# Altium schematic sheet Front end power supplies.SchDoc
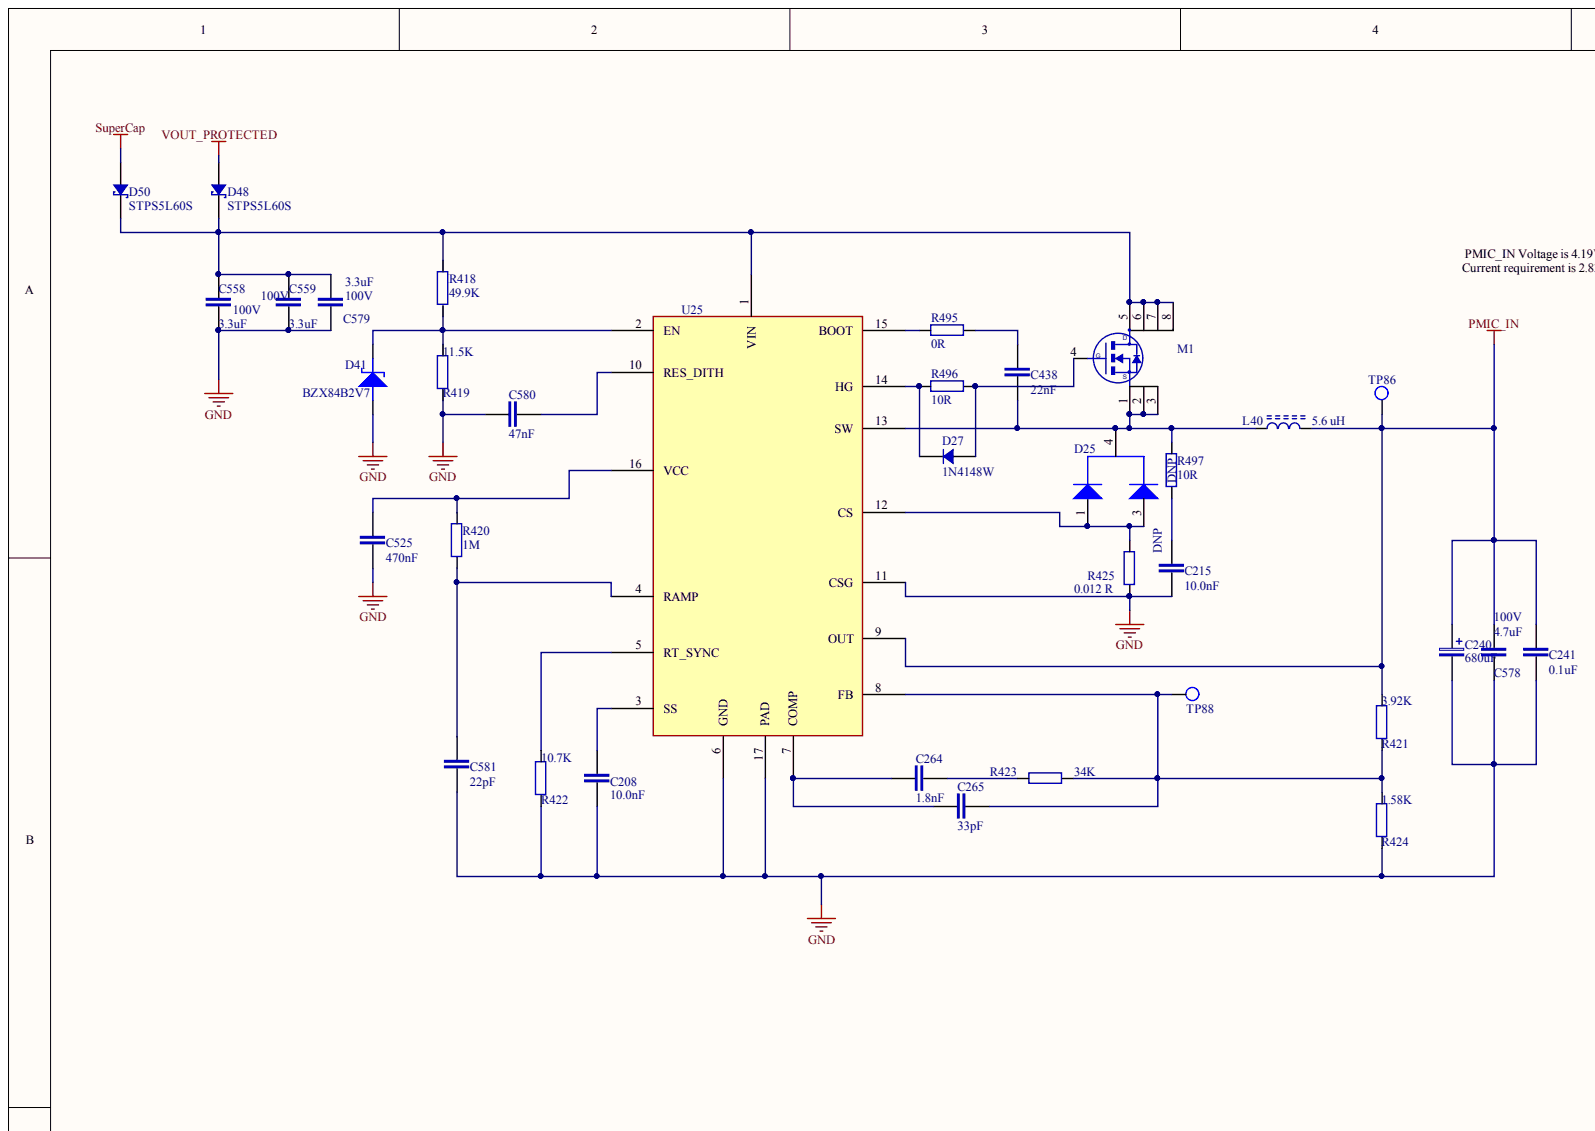
[diagram p0: Front end power supplies.SchDoc, top left]
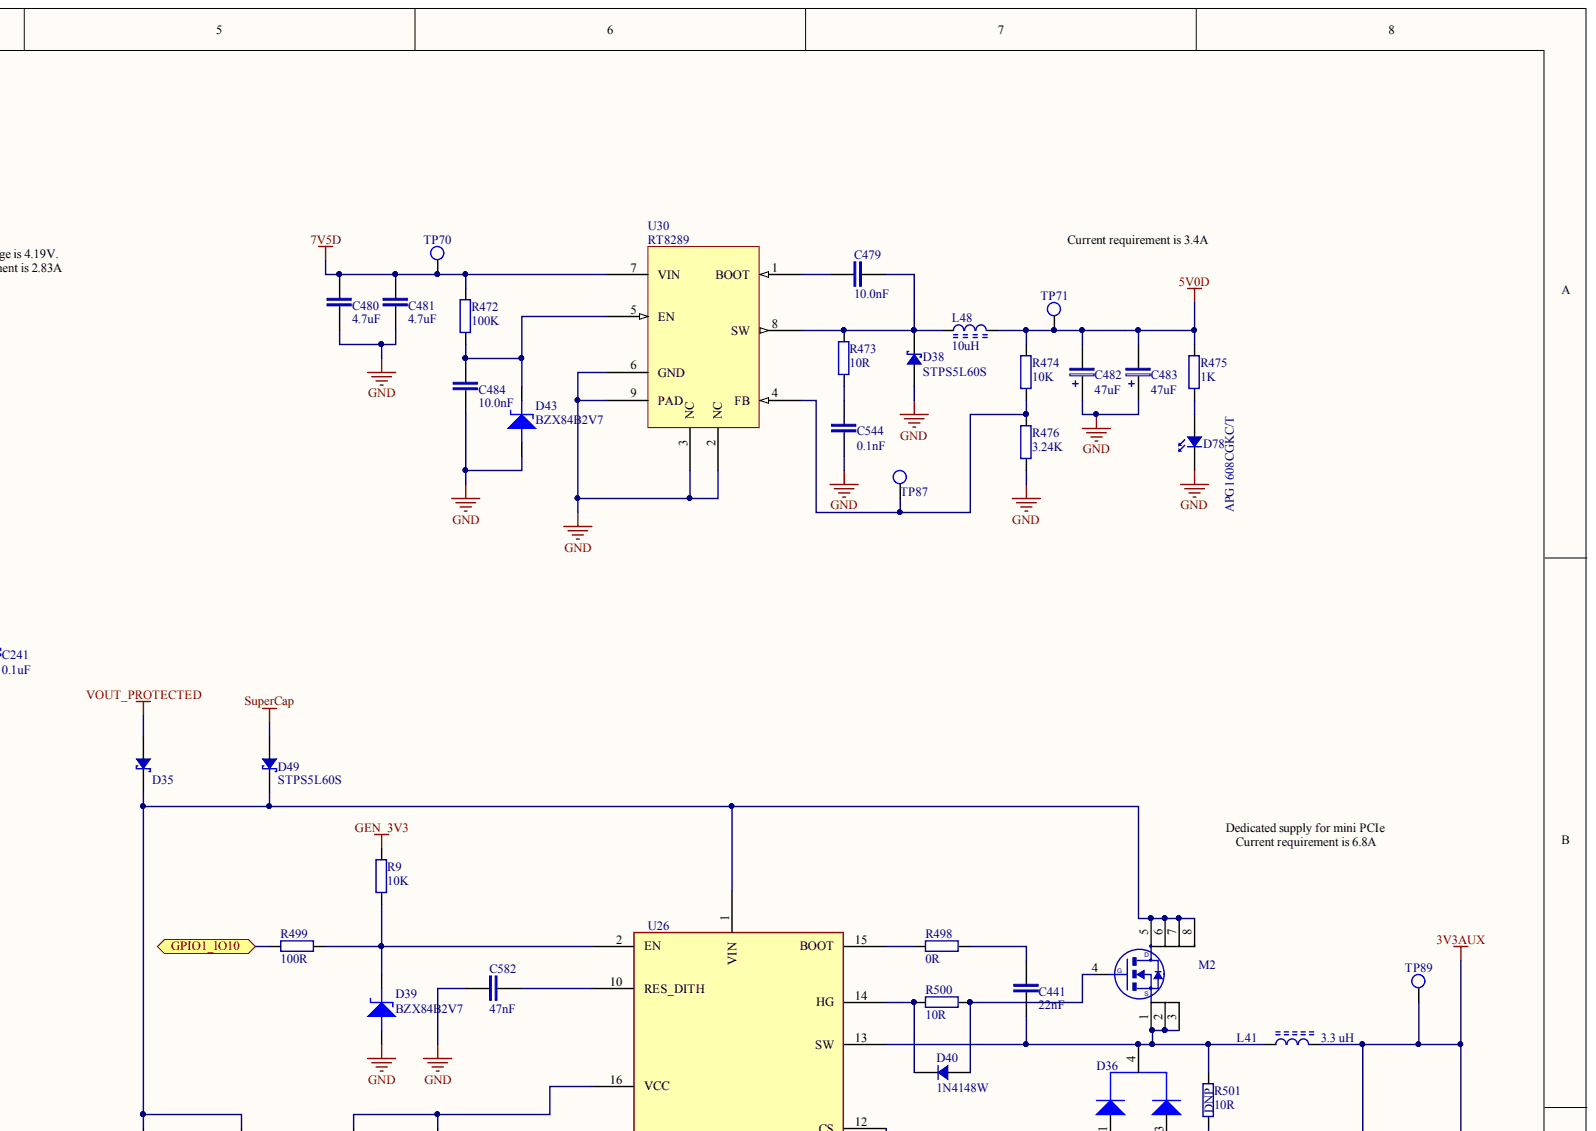
[diagram p1: Front end power supplies.SchDoc, top right]
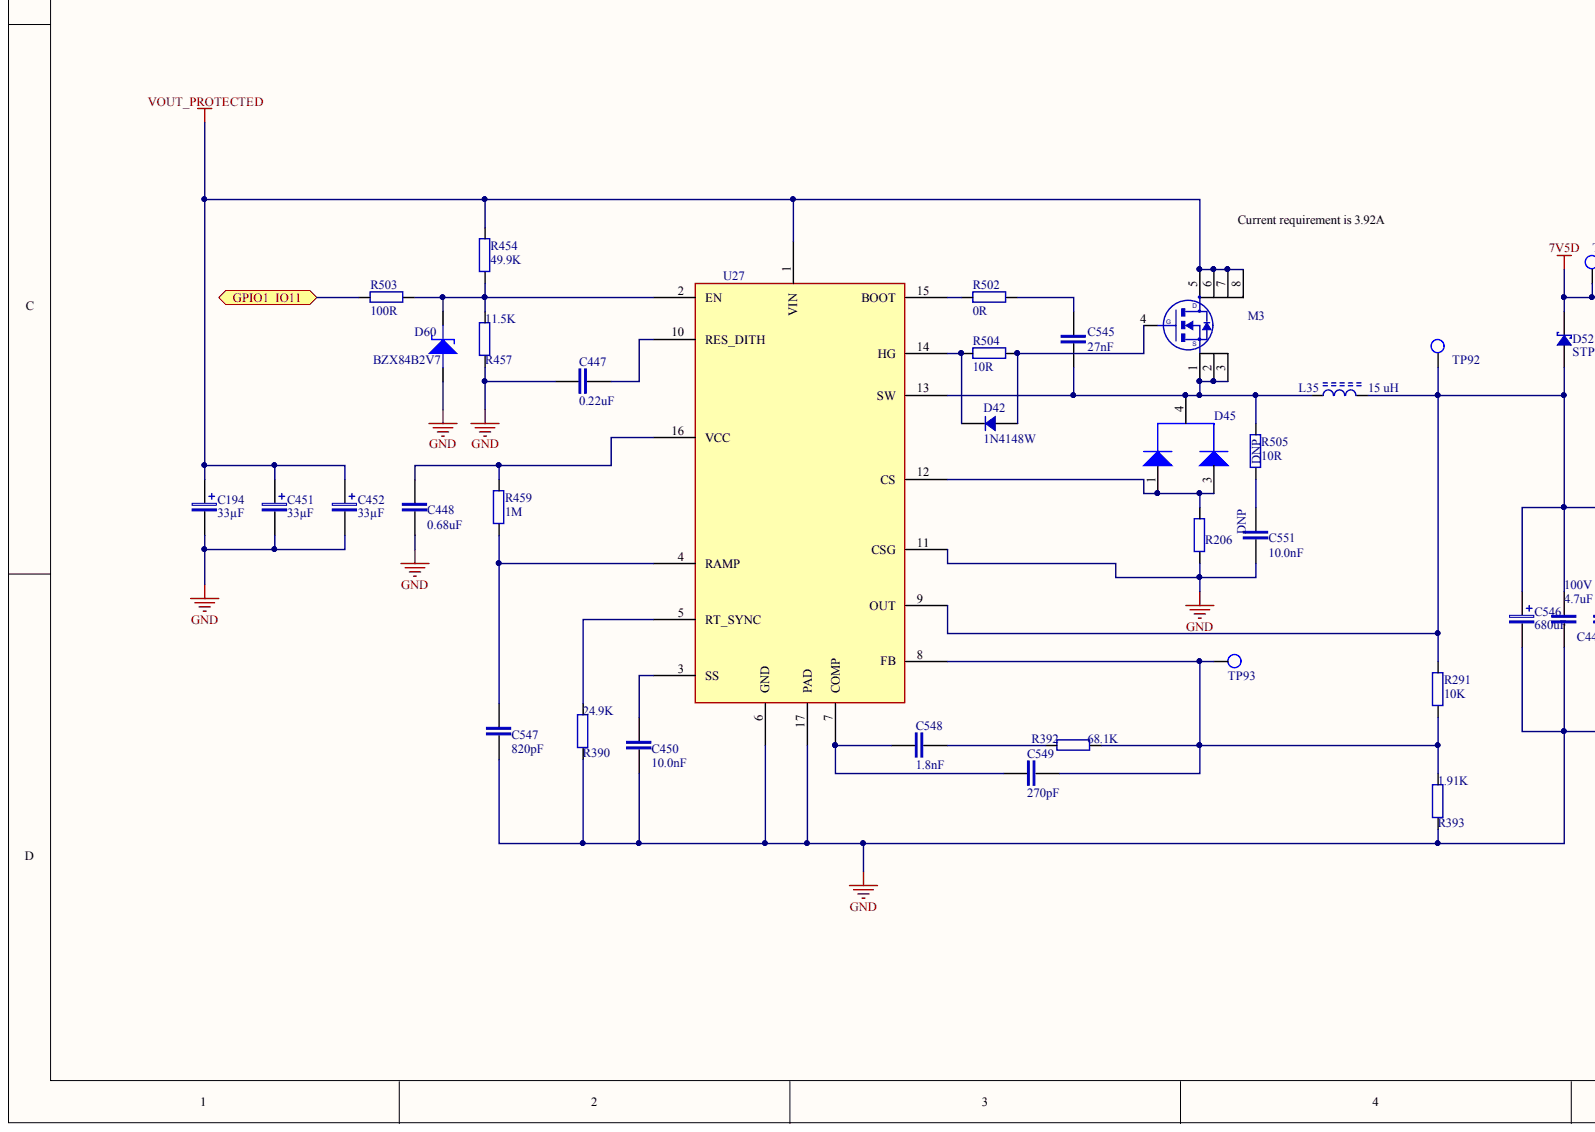
[diagram p2: Front end power supplies.SchDoc, bottom left]
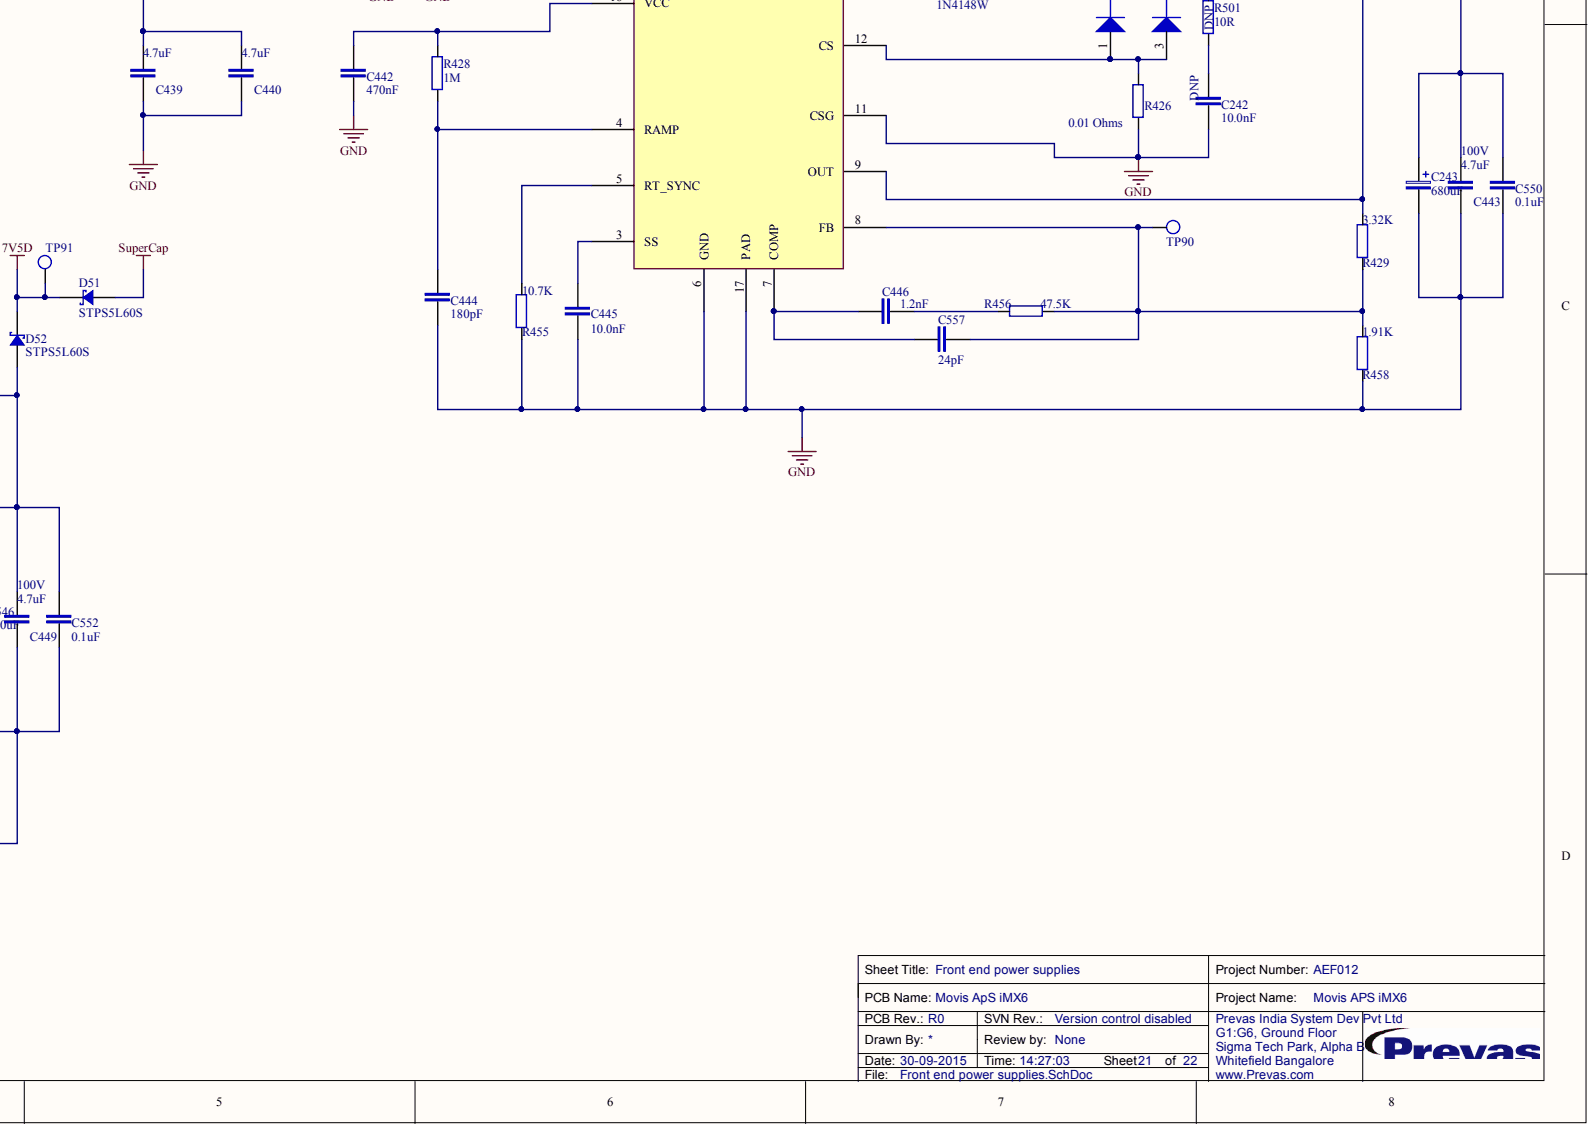
[diagram p3: Front end power supplies.SchDoc, bottom right]

## Parts
C194  33µF  [CAPAE110103X145N]
C208  10.0nF  [CAPC1005X55N]
C215  10.0nF  Fitted: DNP  [CAPC1005X55N]
C240  680uF  [CAPAE8383X10N]
C241  0.1uF  [CAPC1005X55N]
C242  10.0nF  Fitted: DNP  [CAPC1005X55N]
C243  680uF  [CAPAE8383X10N]
C264  1.8nF  [CAPC1005X55N]
C265  33pF  [CAPC1005X55N]
C438  22nF  [CAPC1005X55N]
C439  4.7uF  [CAPC3225X220N]
C440  4.7uF  [CAPC3225X220N]
C441  22nF  [CAPC1005X55N]
C442  470nF  [CAPC1005X55N]
C443  4.7uF  elec_voltage_rating: 100V  [CAPC3225X220N]
C444  180pF  [CAPC1005X55N]
C445  10.0nF  [CAPC1005X55N]
C446  1.2nF  [CAPC1005X55N]
C447  0.22uF  [CAPC1005X55N]
C448  0.68uF  [CAPC1608X95N]
C449  4.7uF  elec_voltage_rating: 100V  [CAPC3225X220N]
C450  10.0nF  [CAPC1005X55N]
C451  33µF  [CAPAE110103X145N]
C452  33µF  [CAPAE110103X145N]
C479  10.0nF  [CAPC1005X55N]
C480  4.7uF  [CAPC1608X90N]
C481  4.7uF  [CAPC1608X90N]
C482  47uF  [CAPMP6032X26N]
C483  47uF  [CAPMP6032X26N]
C484  10.0nF  [CAPC1005X55N]
C525  470nF  [CAPC1005X55N]
C544  0.1nF  [CAPC1005X55N]
C545  27nF  [CAPC1005X55N]
C546  680uF  [CAPAE8383X10N]
C547  820pF  [CAPC1005X55N]
C548  1.8nF  [CAPC1005X55N]
C549  270pF  [CAPC1005X55N]
C550  0.1uF  [CAPC1005X55N]
C551  10.0nF  Fitted: DNP  [CAPC1005X55N]
C552  0.1uF  [CAPC1005X55N]
C557  24pF  [CAPC1005X55N]
C558  3.3uF  elec_voltage_rating: 100V  [CAPC3225X220N]
C559  3.3uF  elec_voltage_rating: 100V  [CAPC3225X220N]
C578  4.7uF  elec_voltage_rating: 100V  [CAPC3225X220N]
C579  3.3uF  elec_voltage_rating: 100V  [CAPC3225X220N]
C580  47nF  [CAPC1005X55N]
C581  22pF  [CAPC1005X55N]
C582  47nF  [CAPC1005X55N]
D25  30CTH02SPbF  [TO254P1524X483-3_4N]  (value not drawn)
D27  1N4148W  [SOD3716X135N]
D35  SK810L-TP  [DIOM7959X262N]  (value not drawn)
D36  30CTH02SPbF  [TO254P1524X483-3_4N]  (value not drawn)
D38  STPS5L60S  [DIOM7959X265N]
D39  BZX84B2V7  [SOT95P240X114-3N]
D40  1N4148W  [SOD3716X135N]
D41  BZX84B2V7  [SOT95P240X114-3N]
D42  1N4148W  [SOD3716X135N]
D43  BZX84B2V7  [SOT95P240X114-3N]
D45  30CTH02SPbF  [TO254P1524X483-3_4N]  (value not drawn)
D48  STPS5L60S  [DIOM7959X265N]
D49  STPS5L60S  [DIOM7959X265N]
D50  STPS5L60S  [DIOM7959X265N]
D51  STPS5L60S  [DIOM7959X265N]
D52  STPS5L60S  [DIOM7959X265N]
D60  BZX84B2V7  [SOT95P240X114-3N]
D78  APG1608CGKC/T  [Kingbright_APG1608CGKC-T]
L35  15 uH  [IND_XAL1010-153MEB]
L40  5.6 uH  [IND_XAL6060-562MEB]
L41  3.3 uH  [IND_XAL7070-332MEB]
L48  10uH  [IND_RLF12545T-100M5R1-PF]
M1  CSD19533Q5A  [SON127P600X110_HS-8N]  (value not drawn)
M2  CSD19533Q5A  [SON127P600X110_HS-8N]  (value not drawn)
M3  CSD19533Q5A  [SON127P600X110_HS-8N]  (value not drawn)
R9  10K  [RESC1608X55N]
R206  0.009 Ohms  [RESC1733X06N]  (value not drawn)
R291  10K  [RESC1608X55N]
R390  24.9K  [RESC1608X55N]
R392  68.1K  [RESC1608X55N]
R393  1.91K  [RESC1608X55N]
R418  49.9K  [RESC1608X55N]
R419  11.5K  [RESC1608X55N]
R420  1M  [RESC1608X55N]
R421  3.92K  [RESC1608X55N]
R422  10.7K  [RESC1608X55N]
R423  34K  [RESC1608X55N]
R424  1.58K  [RESC1608X55N]
R425  0.012 R  [RESC3216X65N]
R426  0.01 Ohms  [RESC1733X06N]
R428  1M  [RESC1608X55N]
R429  3.32K  [RESC1608X55N]
R454  49.9K  [RESC1608X55N]
R455  10.7K  [RESC1608X55N]
R456  47.5K  [RESC1608X55N]
R457  11.5K  [RESC1608X55N]
R458  1.91K  [RESC1608X55N]
R459  1M  [RESC1608X55N]
R472  100K  [RESC1608X55N]
R473  10R  [RESC1608X55N]
R474  10K  [RESC1608X55N]
R475  1K  [RESC1608X55N]
R476  3.24K  [RESC1608X55N]
R495  0R  [RESC3216X65N]
R496  10R  [RESC1608X55N]
R497  10R  Fitted: DNP  [RESC1608X55N]
R498  0R  [RESC3216X65N]
R499  100R  [RESC1608X55N]
R500  10R  [RESC1608X55N]
R501  10R  Fitted: DNP  [RESC1608X55N]
R502  0R  [RESC3216X65N]
R503  100R  [RESC1608X55N]
R504  10R  [RESC1608X55N]
R505  10R  Fitted: DNP  [RESC1608X55N]
TP70  Testpoint  [TP_SMD]  (value not drawn)  (no BOM)
TP71  Testpoint  [TP_SMD]  (value not drawn)  (no BOM)
TP86  Testpoint  [TP_SMD]  (value not drawn)  (no BOM)
TP87  Testpoint  [TP_SMD]  (value not drawn)  (no BOM)
TP88  Testpoint  [TP_SMD]  (value not drawn)  (no BOM)
TP89  Testpoint  [TP_SMD]  (value not drawn)  (no BOM)
TP90  Testpoint  [TP_SMD]  (value not drawn)  (no BOM)
TP91  Testpoint  [TP_SMD]  (value not drawn)  (no BOM)
TP92  Testpoint  [TP_SMD]  (value not drawn)  (no BOM)
TP93  Testpoint  [TP_SMD]  (value not drawn)  (no BOM)
U25  LM5088MH-1_SCH  [SOP65P640X110-17BN]  (value not drawn)
U26  LM5088MH-1_SCH  [SOP65P640X110-17BN]  (value not drawn)
U27  LM5088MH-1_SCH  [SOP65P640X110-17BN]  (value not drawn)
U30  RT8289  [SOIC127P600X175-9AN]

## Ports
GPIO1_IO10, GPIO1_IO11

## Nets
3V3AUX: C243.1 C443.2 C550.1 L41.2 R429.2 TP89.1 U26.9(OUT)
5V0D: C482.2 C483.2 L48.2 R474.2 R475.2 TP71.1
7V5D: C480.2 C481.2 D51.C D52.C R472.2 TP70.1 TP91.1 U30.7(VIN)
GEN_3V3: R9.1
GND: C194.2 C208.1 C215.1 C240.2 C241.2 C242.1 C243.2 C439.1 C440.1 C442.1 C443.1 C444.1 C445.1 C447.1 C448.1 C449.1 C450.1 C451.2 C452.2 C480.1 C481.1 C482.1 C483.1 C484.1 C525.1 C544.1 C546.2 C547.1 C550.2 C551.1 C552.2 C558.1 C559.1 C578.1 C579.1 C580.1 C581.1 C582.1 D38.A D39.1 D41.1 D43.1 D60.1 D78.C R206.1 R390.1 R393.1 R419.1 R422.1 R424.1 R425.1 R426.1 R455.1 R457.1 R458.1 R476.2 U25.6(GND) U25.11(CSG) U25.17(PAD) U26.6(GND) U26.11(CSG) U26.17(PAD) U27.6(GND) U27.11(CSG) U27.17(PAD) U30.2(NC) U30.3(NC) U30.6(GND) U30.9(PAD)
PMIC_IN: C240.1 C241.1 C578.2 L40.2 R421.2 TP86.1 U25.9(OUT)
SUPERCAP: D49.A D50.A D51.A
VOUT_PROTECTED: C194.1 C451.1 C452.1 D35.A D48.A M3.5 M3.6 M3.7 M3.8 R454.2 U27.1(VIN)
GPIO1_IO10: R499.1 ; port GPIO1_IO10
GPIO1_IO11: R503.1 ; port GPIO1_IO11
NetC208_2: C208.2 U25.3(SS)
NetC215_2: C215.2 R497.1
NetC242_2: C242.2 R501.1
NetC264_1: C264.1 C265.1 U25.7(COMP)
NetC264_2: C264.2 R423.1
NetC265_2: C265.2 R421.1 R423.2 R424.2 TP88.1 U25.8(FB)
NetC438_1: C438.1 D25.4 L40.1 M1.1(S) M1.2 M1.3 R497.2 U25.13(SW)
NetC438_2: C438.2 R495.1
NetC439_2: C439.2 C440.2 D35.C D49.C M2.5 M2.6 M2.7 M2.8 U26.1(VIN)
NetC441_1: C441.1 D36.4 L41.1 M2.1 M2.2 M2.3 R501.2 U26.13(SW)
NetC441_2: C441.2 R498.1
NetC442_2: C442.2 R428.2 U26.16(VCC)
NetC444_2: C444.2 R428.1 U26.4(RAMP)
NetC445_2: C445.2 U26.3(SS)
NetC446_1: C446.1 C557.1 U26.7(COMP)
NetC446_2: C446.2 R456.1
NetC447_2: C447.2 U27.10(RES_DITH)
NetC448_2: C448.2 R459.2 U27.16(VCC)
NetC449_2: C449.2 C546.1 C552.1 D52.A L35.2 R291.2 TP92.1 U27.9(OUT)
NetC450_2: C450.2 U27.3(SS)
NetC479_1: C479.1 D38.C L48.1 R473.2 U30.8(SW)
NetC479_2: C479.2 U30.1(BOOT)
NetC484_2: C484.2 D43.3 R472.1 U30.5(EN)
NetC525_2: C525.2 R420.2 U25.16(VCC)
NetC544_2: C544.2 R473.1
NetC545_1: C545.1 D45.4 L35.1 M3.1 M3.2 M3.3 R505.2 U27.13(SW)
NetC545_2: C545.2 R502.1
NetC547_2: C547.2 R459.1 U27.4(RAMP)
NetC548_1: C548.1 C549.1 U27.7(COMP)
NetC548_2: C548.2 R392.1
NetC549_2: C549.2 R291.1 R392.2 R393.2 TP93.1 U27.8(FB)
NetC551_2: C551.2 R505.1
NetC557_2: C557.2 R429.1 R456.2 R458.2 TP90.1 U26.8(FB)
NetC558_2: C558.2 C559.2 C579.2 D48.C D50.C M1.5(D) M1.6 M1.7 M1.8 R418.2 U25.1(VIN)
NetC580_2: C580.2 U25.10(RES_DITH)
NetC581_2: C581.2 R420.1 U25.4(RAMP)
NetC582_2: C582.2 U26.10(RES_DITH)
NetD25_1: D25.1 D25.3 R425.2 U25.12(CS)
NetD27_A: D27.A M1.4(G) R496.1
NetD27_C: D27.C R496.2 U25.14(HG)
NetD36_1: D36.1 D36.3 R426.2 U26.12(CS)
NetD39_3: D39.3 R9.2 R499.2 U26.2(EN)
NetD40_A: D40.A M2.4 R500.1
NetD40_C: D40.C R500.2 U26.14(HG)
NetD41_3: D41.3 R418.1 R419.2 U25.2(EN)
NetD42_A: D42.A M3.4 R504.1
NetD42_C: D42.C R504.2 U27.14(HG)
NetD45_1: D45.1 D45.3 R206.2 U27.12(CS)
NetD60_3: D60.3 R454.1 R457.2 R503.2 U27.2(EN)
NetD78_A: D78.A R475.1
NetR390_2: R390.2 U27.5(RT_SYNC)
NetR422_2: R422.2 U25.5(RT_SYNC)
NetR455_2: R455.2 U26.5(RT_SYNC)
NetR474_1: R474.1 R476.1 TP87.1 U30.4(FB)
NetR495_2: R495.2 U25.15(BOOT)
NetR498_2: R498.2 U26.15(BOOT)
NetR502_2: R502.2 U27.15(BOOT)
